# Altium schematic sheet CORE2.SchDoc(CORE2)
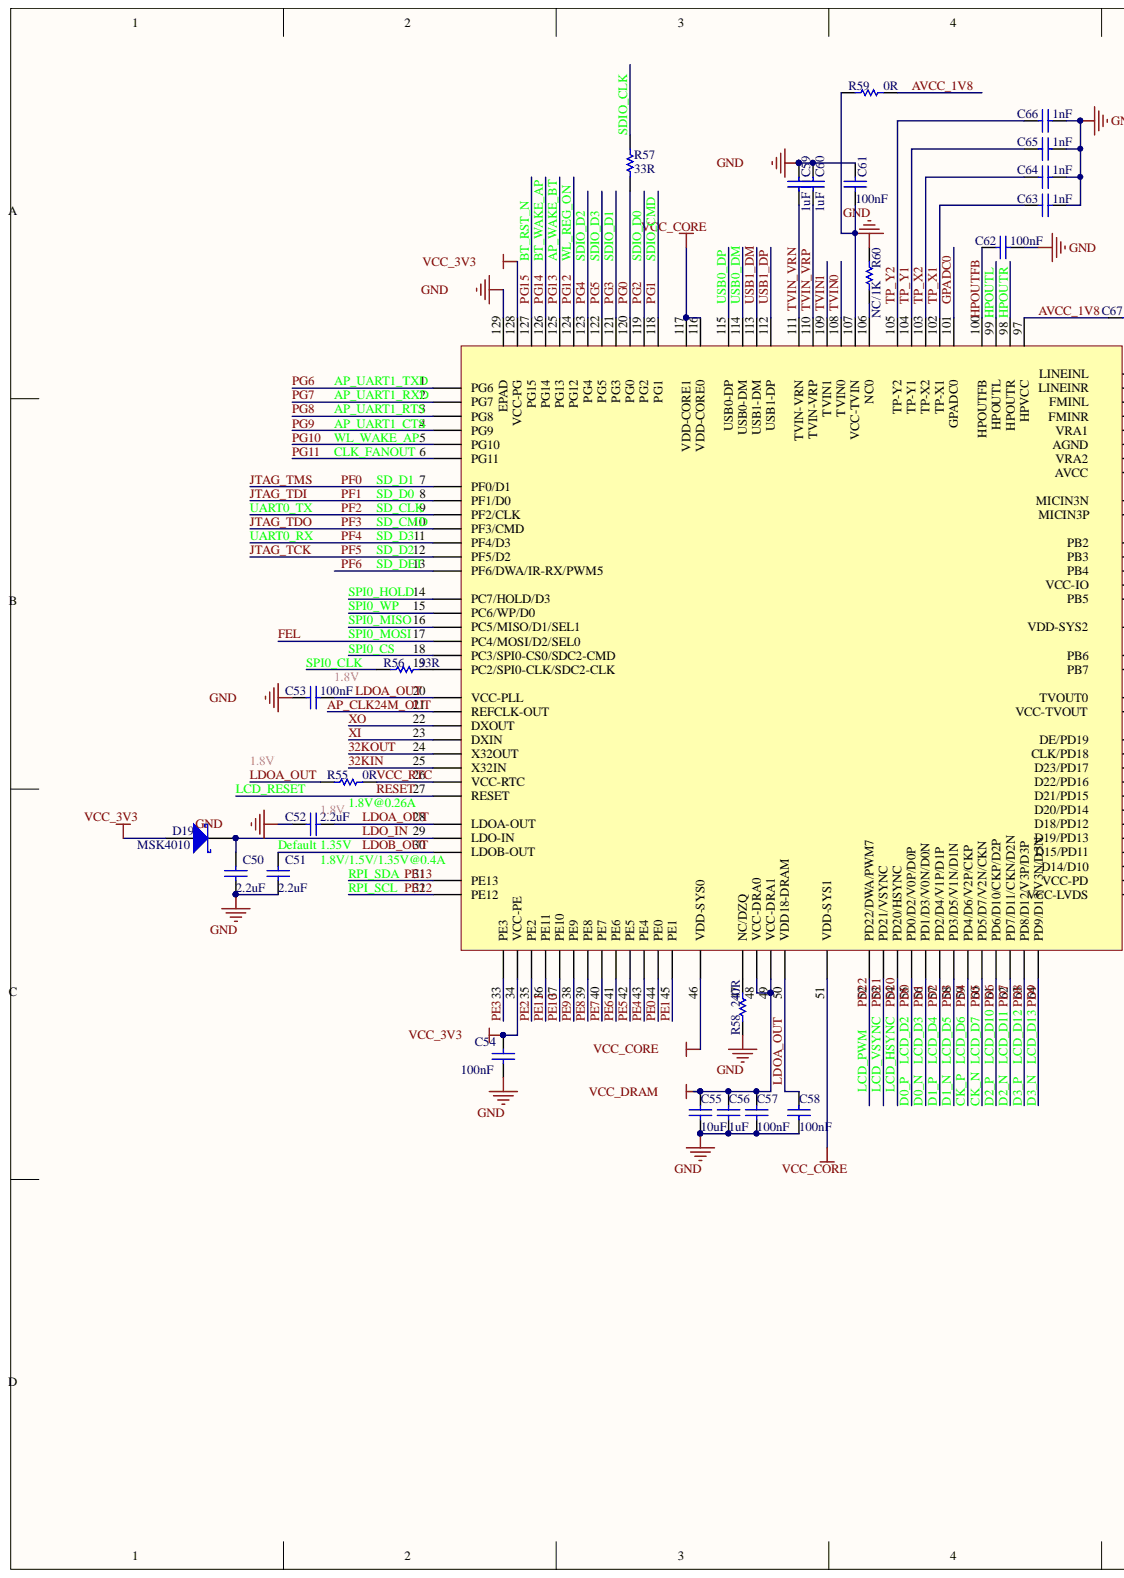
[diagram p0: CORE2.SchDoc, left]
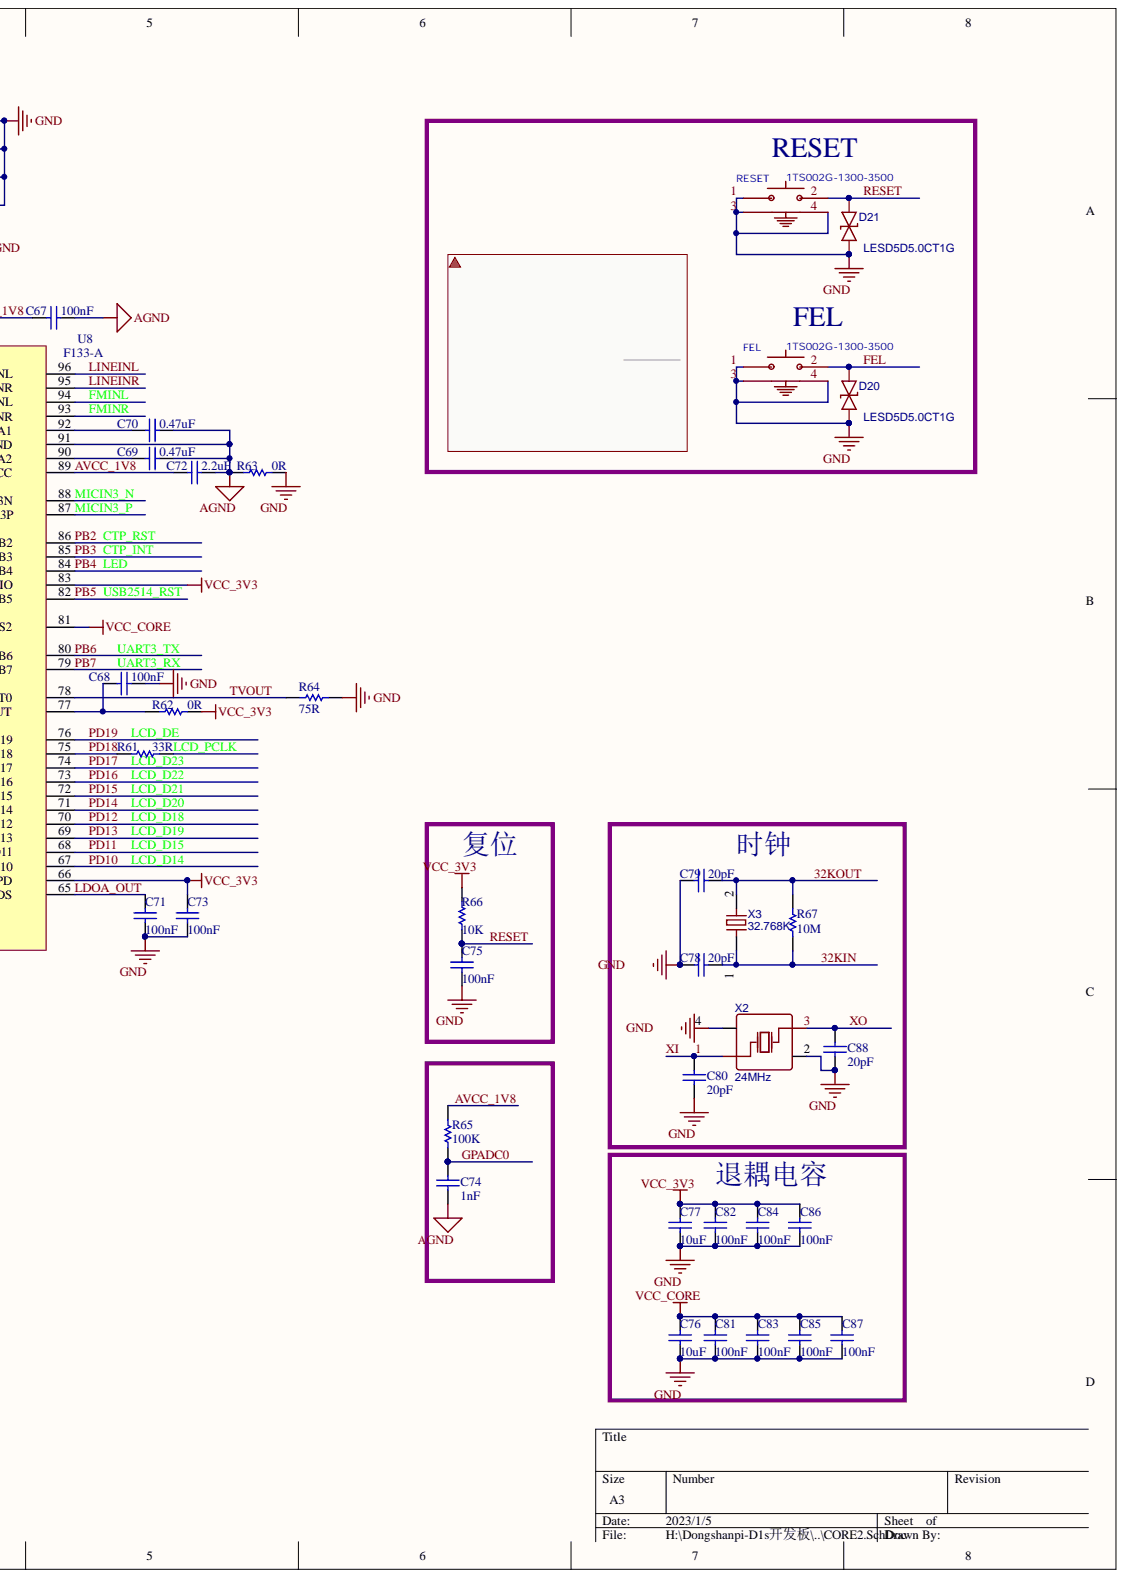
[diagram p1: CORE2.SchDoc, right]

## Parts
C50  2.2uF  [0402]
C51  2.2uF  [0402]
C52  2.2uF  [0402]
C53  100nF  [0402]
C54  100nF  [0402]
C55  10uF  [0402]
C56  1uF  [0402]
C57  100nF  [0402]
C58  100nF  [0402]
C59  1uF  [0402]
C60  1uF  [0402]
C61  100nF  [0402]
C62  100nF  [0402]
C63  1nF  [0402]
C64  1nF  [0402]
C65  1nF  [0402]
C66  1nF  [0402]
C67  100nF  [0402]
C68  100nF  [0402]
C69  0.47uF  [0402]
C70  0.47uF  [0402]
C71  100nF  [0402]
C72  2.2uF  [0402]
C73  100nF  [0402]
C74  1nF  [0402]
C75  100nF  [0402]
C76  10uF  [0402]
C77  10uF  [0402]
C78  20pF  [0402]
C79  20pF  [0402]
C80  20pF  [0402]
C81  100nF  [0402]
C82  100nF  [0402]
C83  100nF  [0402]
C84  100nF  [0402]
C85  100nF  [0402]
C86  100nF  [0402]
C87  100nF  [0402]
C88  20pF  [0402]
D19  MSK4010  [SOD-523F]
D20  LESD5D5.0CT1G  [SOD-523_L1.2-W0.8-LS1.6-BI]
D21  LESD5D5.0CT1G  [SOD-523_L1.2-W0.8-LS1.6-BI]
FEL  1TS002G-1300-3500  [SW-SMD_1TS002G-XXXX-3500-CT]
R55  0R  [0402]
R56  33R  [0402]
R57  33R  [0402]
R58  240R  [0402]
R59  0R  [0402]
R60  NC/1K  [0402]
R61  33R  [0402]
R62  0R  [0402]
R63  0R  [0402]
R64  75R  [0402]
R65  100K  [0402]
R66  10K  [0402]
R67  10M  [0402]
RESET  1TS002G-1300-3500  [SW-SMD_1TS002G-XXXX-3500-CT]
U8  F133-A  [ELQFP128-P0.4]
X2  24MHz  [CRYSTAL-SMD_4P-L2.5-W2.0-BL]
X3  32.768K  [OSC-SMD_L2.0-W1.2]

## Nets
AGND: C67.2 C69.2 C70.2 C72.2 C74.2 R63.2 U8.91
GND: C50.2 C51.2 C52.1 C53.1 C54.2 C55.2 C56.2 C57.2 C58.2 C59.1 C60.1 C61.2 C62.2 C63.1 C64.1 C65.1 C66.1 C68.2 C71.2 C73.2 C75.2 C76.2 C77.2 C78.1 C79.1 C80.2 C81.2 C82.2 C83.2 C84.2 C85.2 C86.2 C87.2 C88.2 D20.1 D21.1 FEL.1 FEL.3 FEL.4 R58.1 R60.1 R63.1 R64.1 RESET.1 RESET.3 RESET.4 U8.129 X2.2 X2.4
VCC_3V3: C54.1 C73.1 C77.1 C82.1 C84.1 C86.1 D19.1 R62.1 R66.2 U8.34 U8.66 U8.83 U8.128
VCC_CORE: C76.1 C81.1 C83.1 C85.1 C87.1 U8.46 U8.51 U8.81 U8.116 U8.117
VCC_DRAM: C55.1 C56.1 C57.1 U8.48 U8.49
32KIN: C78.2 R67.2 U8.25 X3.1
32KOUT: C79.2 R67.1 U8.24 X3.2
AP_CLK24M_OUT: U8.21
AP_UART1_CTS: U8.4
AP_UART1_RTS: U8.3
AP_UART1_RXD: U8.2
AP_UART1_TXD: U8.1
AP_WAKE_BT: U8.125
AVCC_1V8: C67.1 C72.1 R59.1 R65.1 U8.89 U8.97
BT_RST_N: U8.127
BT_WAKE_AP: U8.126
CK_N: U8.60
CK_P: U8.59
CLK_FANOUT: U8.6
CTP_INT: U8.85
CTP_RST: U8.86
D0_N: U8.56
D0_P: U8.55
D1_N: U8.58
D1_P: U8.57
D2_N: U8.62
D2_P: U8.61
D3_N: U8.64
D3_P: U8.63
FEL: D20.2 FEL.2 U8.17
FMINL: U8.94
FMINR: U8.93
GPADC0: C74.1 R65.2 U8.101
HPOUTFB: C62.1 U8.100
HPOUTL: U8.99
HPOUTR: U8.98
JTAG_TCK: U8.12
JTAG_TDI: U8.8
JTAG_TDO: U8.10
JTAG_TMS: U8.7
LCD_D14: U8.67
LCD_D15: U8.68
LCD_D18: U8.70
LCD_D19: U8.69
LCD_D20: U8.71
LCD_D21: U8.72
LCD_D22: U8.73
LCD_D23: U8.74
LCD_DE: U8.76
LCD_HSYNC: U8.54
LCD_PCLK: R61.2
LCD_PWM: U8.52
LCD_RESET: C75.1 D21.2 R66.1 RESET.2 U8.27
LCD_VSYNC: U8.53
LDO_IN: C50.1 D19.2 U8.29
LDOA_OUT: C52.2 C53.2 C58.1 C71.1 R55.2 U8.20 U8.28 U8.50 U8.65
LDOB_OUT: C51.1 U8.30
LED: U8.84
LINEINL: U8.96
LINEINR: U8.95
MICIN3_N: U8.88
MICIN3_P: U8.87
PB5: U8.82
PB6: U8.80
PB7: U8.79
PD18: R61.1 U8.75
PE0: U8.44
PE1: U8.45
PE2: U8.35
PE3: U8.33
PE4: U8.43
PE5: U8.42
PE6: U8.41
PE7: U8.40
PE8: U8.39
PE9: U8.38
PE10: U8.37
PE11: U8.36
PE12: U8.32
PE13: U8.31
PF2: U8.9
PF4: U8.11
PF6: U8.13
PG0: R57.1 U8.120
PG1: U8.118
PG2: U8.119
PG3: U8.121
PG4: U8.123
PG5: U8.122
PG10: U8.5
PG12: U8.124
SDIO_CLK: R57.2
SPI0_CLK: R56.2
SPI0_CS: U8.18
SPI0_HOLD: U8.14
SPI0_MISO: U8.16
SPI0_WP: U8.15
TP_X1: C63.2 U8.102
TP_X2: C64.2 U8.103
TP_Y1: C65.2 U8.104
TP_Y2: C66.2 U8.105
TVIN0: U8.108
TVIN1: U8.109
TVIN_VRN: C59.2 U8.111
TVIN_VRP: C60.2 U8.110
TVOUT: R64.2 U8.78
USB0_DM: U8.114
USB0_DP: U8.115
USB1_DM: U8.113
USB1_DP: U8.112
VCC_RTC: R55.1 U8.26
XI: C80.1 U8.23 X2.1
XO: C88.1 U8.22 X2.3
NetC61_1: C61.1 R59.2 U8.107
NetC68_1: C68.1 R62.2 U8.77
NetC69_1: C69.1 U8.90
NetC70_1: C70.1 U8.92
NetR56_1: R56.1 U8.19
NetR58_2: R58.2 U8.47
NetR60_2: R60.2 U8.106

## Library data of the parts
C50-C88 = Cap: Capacitor; PartNumber: CAP100NF; Component Kind: Standard; LatestRevisionDate: 17-Jul-2002; LatestRevisionNote: Re-released for DXP Platform.; Library Name: Miscellaneous Devices.IntLib; PackageReference: 0402; Published: 8-Jun-2000; Signal Integrity: Cap; Simulation: CAP; Publisher: Altium Limited
  C50-C52 C72: Value: 2.2uF
  C53 C54 C57 C58 C61 C62 C67 C68 C71 C73 C75 C81-C87: Value: 100nF
  C55 C76 C77: Value: 10uF
  C56 C59 C60: Value: 1uF
  C63-C66 C74: Value: 1nF
  C69 C70: Value: 0.47uF
  C78-C80 C88: Value: 20pF
D19 = D Schottky: Schottky Diode; Code_JEDEC: DO-214-AA; Component Kind: Standard; LatestRevisionDate: 17-Jul-2002; LatestRevisionNote: Re-released for DXP Platform.; Library Name: HM2.SchLib; PackageDescription: DO-214-AA/SMB; 2 C-Bend Leads; Body 5.3 x 3.6 mm, inc. leads (LxW); PackageReference: SMB; Published: 8-Jun-2000; Signal Integrity: D Schottky; Simulation: SKYDIODE; Publisher: Altium Limited
D20 D21 = LESD5D5.0CT1G: BOM_Manufacturer: Leshan Radio; Contributor: LCSC; BOM_JLCPCB Part Class: Extended Part; BOM_Manufacturer Part: LESD5D5.0CT1G; BOM_Supplier: LCSC; BOM_Supplier Part: C383211; Component Kind: Standard; spicePre: D; spiceSymbolName: LESD5D5.0CT1G
R55-R67 = Res1: Resistor; PartNumber: RES2.2K; Component Kind: Standard; LatestRevisionDate: 17-Jul-2002; LatestRevisionNote: Re-released for DXP Platform.; Library Name: Miscellaneous Devices.IntLib; PackageReference: 0402; Published: 8-Jun-2000; Signal Integrity: Res; Simulation: RESISTOR; Publisher: Altium Limited
  R55 R59 R62 R63: Value: 0R
  R56 R57 R61: Value: 33R
  R58: Value: 240R
  R60: Value: NC/1K
  R64: Value: 75R
  R65: Value: 100K
  R66: Value: 10K
  R67: Value: 10M
U8 = F133: Component Kind: Standard; Library Name: HM2.SchLib
X2 = 24MHz_1: BOM_Manufacturer: JGHC; BOM_Manufacturer Part: X252024MPB4SC; Contributor: LCSC; nameAlias: Frequency; BOM_Supplier: LCSC; BOM_Supplier Part: C65961; Component Kind: Standard; Library Name: HM2.SchLib; spicePre: X; spiceSymbolName: X252024MPB4SC
X3 = -: BOM_Manufacturer Part: TFX-03C-32.768K; Contributor: LCSC; nameAlias: Frequency; BOM_JLCPCB Part Class: Extended Part; BOM_Supplier: LCSC; BOM_Supplier Part: C515344; Component Kind: Standard; spicePre: X; spiceSymbolName: TFX-03C-32.768K
FEL RESET = 1TS002G-1300-3500: Component Kind: Standard; JLC NO: C255803
